# Altium schematic sheet Sheet1.SchDoc
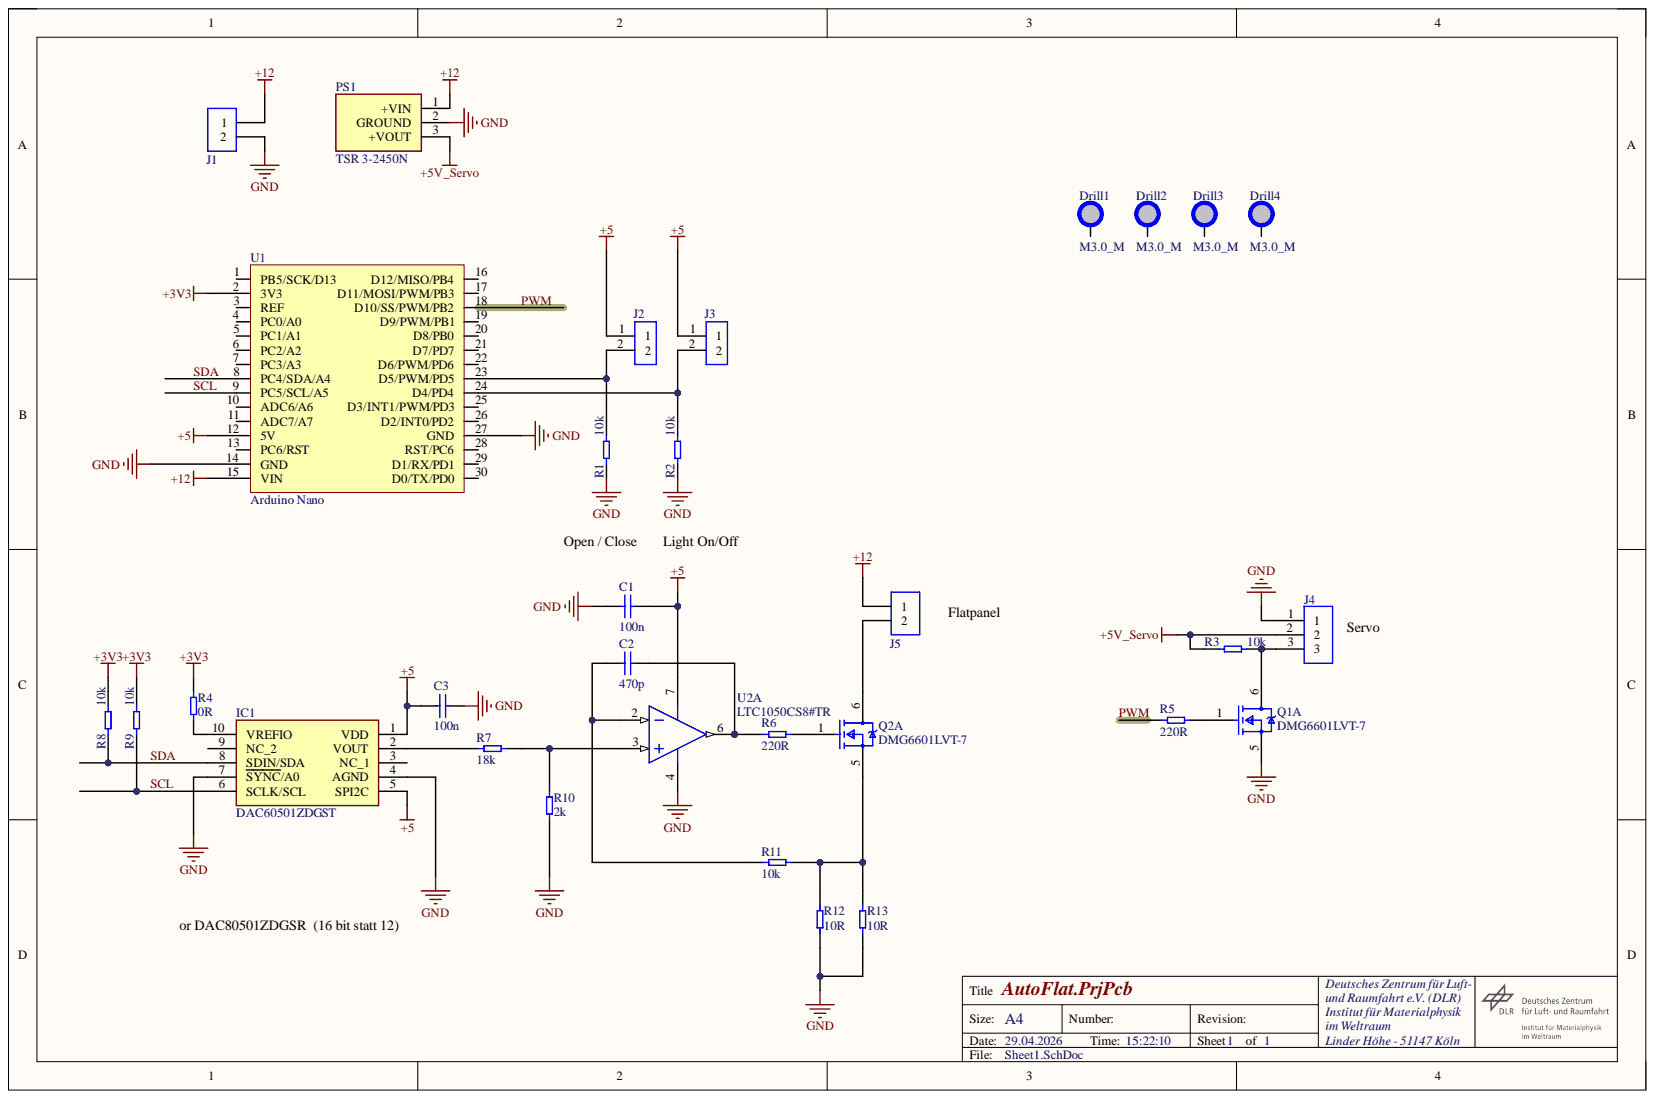
[diagram p0: Sheet1.SchDoc]

## Parts
C1  100n  [C0603_L]
C2  470p  [C0603_L]
C3  100n  [C0603_L]
Drill1  M3.0_M  [M3.0_M]  (no BOM)
Drill2  M3.0_M  [M3.0_M]  (no BOM)
Drill3  M3.0_M  [M3.0_M]  (no BOM)
Drill4  M3.0_M  [M3.0_M]  (no BOM)
IC1  DAC60501ZDGST  [SOP50P490X110-10N]
J1  PM_5.08_3_90_3.5_SW_-176052000  [MKDSN 5,08/2 2,5]  (value not drawn)
J2  896-7620  [2P Stiftleiste 2,54 mm]  (value not drawn)
J3  896-7620  [2P Stiftleiste 2,54 mm]  (value not drawn)
J4  251-8092  [3P Stiftleiste 2,54 mm]  (value not drawn)
J5  PM_5.08_3_90_3.5_SW_-176052000  [MKDSN 5,08/2 2,5]  (value not drawn)
PS1  TSR 3-2450N  [TSR324120N]
Q1  DMG6601LVT-7  [FP-TSOT26-MFG]  (part A)
Q2  DMG6601LVT-7  [FP-TSOT26-MFG]  (part A)
R1  10k  [R0603_M]
R2  10k  [R0603_M]
R3  10k  [R0603_M]
R4  0R  [R0603_M]
R5  220R  [R0603_M]
R6  220R  [R0603_M]
R7  18k  [R0603_M]
R8  10k  [R0603_M]
R9  10k  [R0603_M]
R10  2k  [R0603_M]
R11  10k  [R0603_M]
R12  10R  [R0805_M]
R13  10R  [R0805_M]
U1  Arduino Nano  Manufacturer: Arduino  [Arduino Nano]
U2  LTC1050CS8#TR  [LT-S8-8_L]  (part A)

## Nets
+3V3: R4.1 R8.1 R9.1 U1.2(3V3)
+5: C1.1 C3.1 IC1.1(VDD) IC1.5(SPI2C) J2.1 J3.1 U1.12(5V) U2.7
+5V_Servo: J4.2 PS1.3 R3.1
+12: J1.1 J5.1 PS1.1 U1.15(VIN)
GND: C1.2 C3.2 IC1.4(AGND) IC1.7(SYNC/A0) J1.2 J4.1 PS1.2 Q1.5 R1.2 R2.2 R10.1 R12.1 R13.1 U1.14(GND) U1.27(GND) U2.4
PWM: R5.1 U1.18(D10/SS/PWM/PB2)
SCL: IC1.6(SCLK/SCL) R9.2 U1.9(PC5/SCL/A5)
SDA: IC1.8(SDIN/SDA) R8.2 U1.8(PC4/SDA/A4)
NetC2_1: C2.1 R11.1 U2.2
NetC2_2: C2.2 R6.1 U2.6
NetIC1_2: IC1.2(VOUT) R7.1
NetIC1_10: IC1.10(VREFIO) R4.2
NetJ2_2: J2.2 R1.1 U1.23(D5/PWM/PD5)
NetJ3_2: J3.2 R2.1 U1.24(D4/PD4)
NetJ4_3: J4.3 Q1.6 R3.2
NetJ5_2: J5.2 Q2.6
NetQ1_1: Q1.1 R5.2
NetQ2_1: Q2.1 R6.2
NetQ2_5: Q2.5 R11.2 R12.2 R13.2
NetR7_2: R7.2 R10.2 U2.3

Not connected: Drill1 1; Drill2 1; Drill3 1; Drill4 1; IC1 3(NC_1) 9(NC_2); U1 1(PB5/SCK/D13) 3(REF) 4(PC0/A0) 5(PC1/A1) 6(PC2/A2) 7(PC3/A3) 10(ADC6/A6) 11(ADC7/A7) 13(PC6/RST) 16(D12/MISO/PB4) 17(D11/MOSI/PWM/PB3) 19(D9/PWM/PB1) 20(D8/PB0) 21(D7/PD7) 22(D6/PWM/PD6) 25(D3/INT1/PWM/PD3) 26(D2/INT0/PD2) 28(RST/PC6) 29(D1/RX/PD1) 30(D0/TX/PD0)

## Library data of the parts
C1-C3 = C0603: .CHECKED PCB: RF; Component Kind: Standard; LatestRevisionDate: 29-May-2009; LatestRevisionNote: IPC-7351 Footprint Added.; PackageDescription: Chip Capacitor; PackageReference: C1206; Published: 8-Jun-2000; Signal Integrity: Cap Semi; Simulation: CAP; .CHECKED SCH: RF; Library Name: Passive Bauelemente.SchLib; Publisher: Altium Limited
Drill1-Drill4 = Drill: .CHECKED PCB: RF; .CHECKED SCH: RF; Library Name: Drills.SchLib
IC1 = DAC60501ZDGST: Integrated Circuit; Manufacturer_Name: Texas Instruments; Arrow Part Number: DAC60501ZDGST; Arrow Price/Stock: https://www.arrow.com/en/products/dac60501zdgst/texas-instruments?region=nac; Component Kind: Standard; Datasheet Link: https://www.ti.com/lit/gpn/DAC60501; Height: 1.1mm; Manufacturer_Part_Number: DAC60501ZDGST; Mouser Part Number: 595-DAC60501ZDGST; Mouser Price/Stock: https://www.mouser.co.uk/ProductDetail/Texas-Instruments/DAC60501ZDGST?qs=%252B6g0mu59x7IgTz6VyILPDQ%3D%3D
J1 J5 = MKDSN 5,08/2 2,5: 间距5.08mm 2pin 10A 300V 可拼接 螺钉式 直插 配线24-14AWG(0.2-2.5)mm²; Manufacturer: Phoenix Contact; Manufacturer Part Number: 1888687; .CHECKED PCB: PS; Approvals: UL,VDE,cULus,CB,EAC; Connector Type: Screw; Current Rating: 16A; Gender: Male; Max Supply Voltage: 250V; Number of Circuits: 2; Number of Levels: 1; Pitch: 5.08mm; Voltage Rating: 400V; Wire Gauge (Max): 30AWG; Wire Gauge (Min): 12AWG; Wire/Cable Gauge: 24AWG; Category: Terminal Blocks; Color: Green; Component Kind: Standard; ComponentLink1Description: https://datasheet.ciiva.com/5765/528137-5765413.pdf?src-supplier=Farnell; ComponentLink1URL: https://datasheet.ciiva.com/5765/528137-5765413.pdf?src-supplier=Farnell; ComponentLink2Description: https://datasheet.ciiva.com/16047/1888687-16047138.pdf?src-supplier=Onlinecomponents.com; ComponentLink2URL: https://datasheet.ciiva.com/16047/1888687-16047138.pdf?src-supplier=Onlinecomponents.com; ComponentLink3Description: https://datasheet.ciiva.com/6751/1888687-6751366.pdf?src-supplier=Digi-Key; ComponentLink3URL: https://datasheet.ciiva.com/6751/1888687-6751366.pdf?src-supplier=Digi-Key; ComponentLink4Description: https://datasheet.ciiva.com/29226/774087-da-01-en-printklemme-1888687-250-st-29226666.pdf?src-supplier=Conrad; ComponentLink4URL: https://datasheet.ciiva.com/29226/774087-da-01-en-printklemme-1888687-250-st-29226666.pdf?src-supplier=Conrad; Contact Material: Tin; Current: 10A; Depth: 9.5mm; Flammability Rating: UL94 V-0; Height: 10.16mm; Housing Color: Green; Housing Material: Polyamide; Lead Free: Lead Free; Length: 11.6mm; Library Name: Phoenix Contact.SchLib; Material: Polyamide; Max Current Rating: 16A; Mount: Through Hole; Number of Contacts: 2; Number of Pins: 2; Number of Poles: 2; Number of Positions: 2; Number of Positions Per Level: 2; Number of Rows: 1; Orientation: Straight; Package Quantity: 50; Packaging: Bulk; REACH SVHC: Unknown; RoHS: Yes; RoHS Compliant: Yes; Series: COMBICON MKDSN; Stock 1: 462; Supplier 1: RSComponents; Supplier 2: Farnell; Supplier Part Number 1: 8045095; Supplier Part Number 2: 1792766; Termination: Screw; Voltage: 300V; Weight: 0.114993oz; Width: 9.5mm; .CHECKED SCH: PS
J2 J3 = 2P Stiftleiste 2,54: 7 Pin Stiftleiste Raster 2,54 mm  2.54mm Pitch 7 Way 1 Row Straight PCB Header, Solder Termination  RS Nr.: 896-7620; Manufacturer_Name: Molex; Manufacturer_Part_Number: 42375-0002; .CHECKED PCB: RF; Geometry.Height: 8.69mm; Component Kind: Standard; Datasheet Link: https://componentsearchengine.com/Datasheets/3/61300711121.pdf; RS Part Number: 896-7620; .CHECKED SCH: RF; Library Name: EigenDefault.SchLib
J4 = 3P Stiftleiste 2,54: 3 Pin Stiftleiste Raster 2,54 mm  Wurth Elektronik WR-PHD Series, Series Number 6130, 2.54mm Pitch 7 Way 1 Row Straight PCB Header, Solder Termination  RS Nr.: 61300711121; .CHECKED PCB: RF; Geometry.Height: 8.69mm; Component Kind: Standard; Datasheet Link: https://docs-emea.rs-online.com/webdocs/1584/0900766b81584a98.pdf; Manufacturer_Name: RS; Manufacturer_Part_Number: 251-8092; RS Part Number: 251-8092; .CHECKED SCH: RF; Library Name: EigenDefault.SchLib
PS1 = TSR_3-24120N: or TSR 3-2450N; Manufacturer_Name: Traco Power; Component Kind: Standard; Datasheet Link: https://tracopower.com/tsr3n-datasheet/; Height: 10.7mm; Manufacturer_Part_Number: TSR 3-2450N; Mouser Part Number: TSR 3-2450N; Mouser Price/Stock: https://www.mouser.co.uk/ProductDetail/TRACO-Power/TSR-3-24120N?qs=7%2F6SraaimPQ2uf1LtAOePA%3D%3D
Q1 Q2 = CMP-2000-06173-2: MOSFET N/P-CH 30V 26TSOT; Manufacturer: Diodes Inc.; Automotive Grade: Grade 0; Continuous Drain Current: 3.8/-2.5A; Depletion Mode: False; Drain To Source Resistance: 34/70mΩ; Gate To Source Voltage: 12V; Max Forward Diode Voltage: 1V; Max Junction Temp: +150°C; Number Of Channels: 2; Number Of N Channels: 1; Power Dissipation: 0.85W; Pulse Drain Current: 20/-15A; Rthja Max: 147°C/W; Standoff Height: 0.01mm; Threshold Vgs Max: 1.5/-1.2V; Threshold Vgs Min: 0.5/-0.4V; Turn Off Delay Time: 31.2/18.3ns; Turn On Delay Time: 1.6/1.7ns; Typ Forward Diode Voltage: 0.75/-0.8V; Automotive: Yes; Category: Trans; Component Kind: Standard; ComponentLink2Description: Datasheet; ComponentLink2URL: https://www.diodes.com/assets/Datasheets/DMG6601LVT.pdf; Device Class L1: Discrete Semiconductors; Device Class L2: Transistors; Device Class L3: MOSFETs; Digikey Description: MOSFET N/P-CH 30V 26TSOT; Drain To Source Breakdown Voltage: 30V; Drain To Source Voltage: 30V; Footprint Url: https://www.diodes.com/assets/Package-Files/TSOT26.pdf; Height: 1mm; Ipc Land Pattern Name: SOT95P280X100-6; Lead Free: Yes; Library Name: Altium Content Vault; Manufacturer Part Number: DMG6601LVT-7; Mouser Description: N & P-Channel 30 V 110 mO Complementary Pair Enhancement Mode Mosfet; Number Of P Channels: 1; Octopart: https://octopart.com/dmg6601lvt-7-diodes+inc.-24548281; Package: TSOT26; RoHS: TRUE; Temperature: -55°C to +150°C; Temperature Range High: +150°C; Temperature Range Low: -55°C
R1-R11 = R0603: Manufacture: Bourns; Manufacture Nr: PWR263S-35-7R50F; .CHECKED PCB: RF; Gehäuse: T0-263; Power: 35 W; Value: 7,5 Ohm; Component Kind: Standard; Datasheet: https://docs-emea.rs-online.com/webdocs/0f73/0900766b80f73c76.pdf; Distributor: RS; Distributor Nr: 733-2688; LatestRevisionDate: 17-Jul-2002; LatestRevisionNote: Re-released for DXP Platform.; PackageDescription: Axial Device, Thru-Hole; 2 Leads; 0.3 in Pin Spacing; PackageReference: AXIAL-0.3; Published: 8-Jun-2000; .CHECKED SCH: RF; Library Name: Passive Bauelemente.SchLib; Publisher: Altium Limited
R12 R13 = R0805: Manufacture: Bourns; Manufacture Nr: PWR263S-35-7R50F; .CHECKED PCB: RF; Gehäuse: T0-263; Power: 35 W; Value: 7,5 Ohm; Component Kind: Standard; Datasheet: https://docs-emea.rs-online.com/webdocs/0f73/0900766b80f73c76.pdf; Distributor: RS; Distributor Nr: 733-2688; LatestRevisionDate: 17-Jul-2002; LatestRevisionNote: Re-released for DXP Platform.; PackageDescription: Axial Device, Thru-Hole; 2 Leads; 0.3 in Pin Spacing; PackageReference: AXIAL-0.3; Published: 8-Jun-2000; .CHECKED SCH: RF; Library Name: Passive Bauelemente.SchLib; Publisher: Altium Limited
U1 = Arduino Nano: Nano Every Development Brd, 8Bit Avr Mcu Rohs Compliant: Yes; Manufacturer Part Number: ABX00028; .PCB Checked: PS; Category: Embedded Processors and Controllers; Component Kind: Standard; Library Name: Arduino System.SchLib; RoHS: No; Stock 1: 489; Supplier 1: Digi-Key; Supplier Part Number 1: 1050-ABX00028-ND; .SCH Checked: PS
U2 = CMP-0359-03069-2: Single Channel, Precision, Zero-Drift Operational Amplifier with Internal Capacitors, 2.5 MHz Typical GBW, 4 V/us Typical SR, 4.75 to 18 V, 8-Pin SOIC (S8-8), Commercial, Reel; Manufacturer: Linear Technology; Channels (#): 1; GBW (MHz) Typical: 2.5; SR (V/us) Typical: 4; Vos (mV) Typical: 0.0005; Vs Max (V): 18; Vs Min (V): 4.75; Component Kind: Standard; ComponentLink1Description: Manufacturer URL; ComponentLink1URL: http://www.linear.com/; ComponentLink2Description: Datasheet; ComponentLink2URL: http://cds.linear.com/docs/en/datasheet/1050fb.pdf; ComponentLink3Description: Package Specification; ComponentLink3URL: http://cds.linear.com/docs/en/packaging/05081610_G_SO8.pdf; Is (mA) Typical: 1; Library Name: Altium Content Vault; Linear Technology Part Number: LTC1050; Mounting Technology: Surface Mount; PackageDescription: 8-Pin Plastic Small Outline, Body 4.9 x 3.9 mm, Pitch 1.27 mm; PackageReference: LT-S8-8; PackageVersion: Rev G; Packing: Reel; PartNumber: LTC1050CS8#TR; RevisionNote: URLs updated; Type: ZD
